# Altium schematic sheet BGT.SchDoc
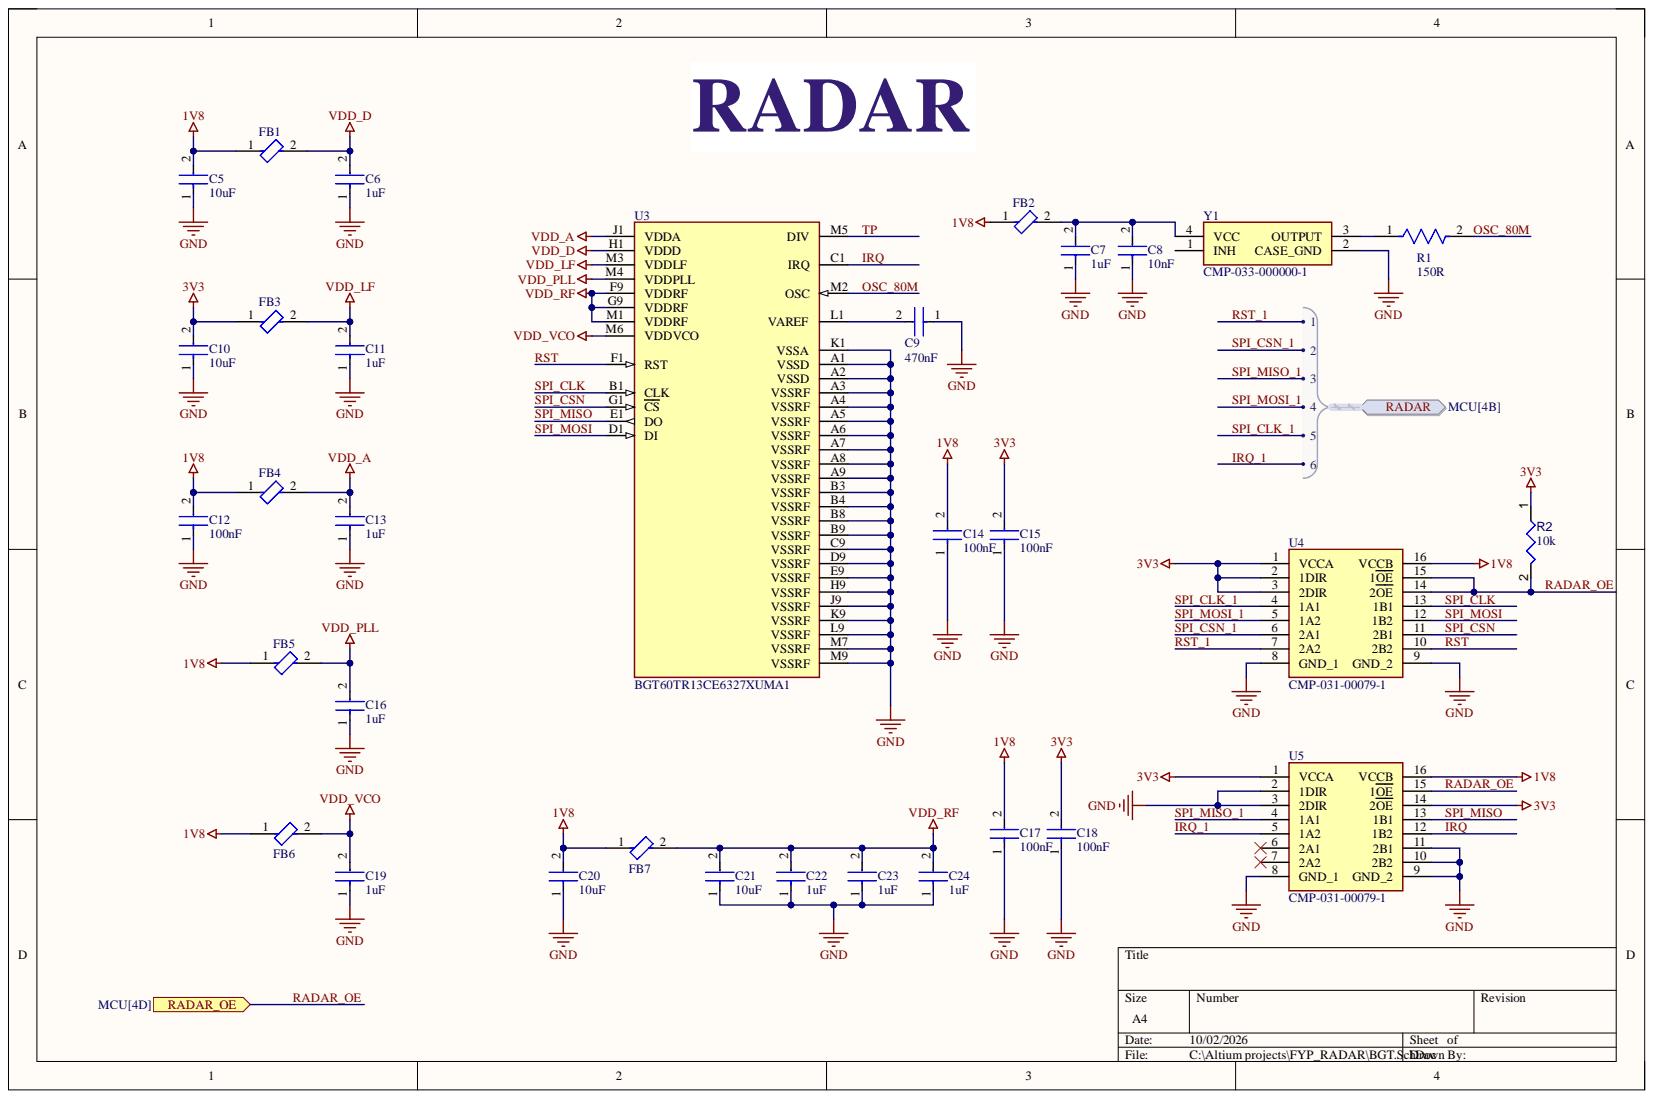
[diagram p0: BGT.SchDoc]

## Parts
C5  10uF  [FP-C0402C106M9PACTU-MFG]
C6  1uF  [FP-CC0402-MFG]
C7  1uF  [FP-CC0402-MFG]
C8  10nF  [FP-C0402-BB-MFG]
C9  470nF  [FP-CC0402-MFG]
C10  10uF  [FP-C0402C106M9PACTU-MFG]
C11  1uF  [FP-CC0402-MFG]
C12  100nF  [FP-C0402-BB-MFG]
C13  1uF  [FP-CC0402-MFG]
C14  100nF  [FP-C0402-BB-MFG]
C15  100nF  [FP-C0402-BB-MFG]
C16  1uF  [FP-CC0402-MFG]
C17  100nF  [FP-C0402-BB-MFG]
C18  100nF  [FP-C0402-BB-MFG]
C19  1uF  [FP-CC0402-MFG]
C20  10uF  [FP-C0402C106M9PACTU-MFG]
C21  10uF  [FP-C0402C106M9PACTU-MFG]
C22  1uF  [FP-CC0402-MFG]
C23  1uF  [FP-CC0402-MFG]
C24  1uF  [FP-CC0402-MFG]
FB1  MMZ1005B601CT000  [FP-MMZ1005-IPC_A]  (value not drawn)
FB2  MMZ1005B601CT000  [FP-MMZ1005-IPC_A]  (value not drawn)
FB3  MMZ1005B601CT000  [FP-MMZ1005-IPC_A]  (value not drawn)
FB4  MMZ1005B601CT000  [FP-MMZ1005-IPC_A]  (value not drawn)
FB5  MMZ1005B601CT000  [FP-MMZ1005-IPC_A]  (value not drawn)
FB6  MMZ1005S121CT000  [FP-MMZ1005-IPC_C]  (value not drawn)
FB7  MMZ1005S121CT000  [FP-MMZ1005-IPC_C]  (value not drawn)
R1  150R  [FP-AF0402-IPC_C]
R2  value 10k  [RESC2013X50X35ML15T15]  (hidden comment: Resistor 10k +/-1% 0805 125 mW)
U3  BGT60TR13CE6327XUMA1  [FP-PG-VF2BGA-40-MFG]
U4  CMP-031-00079-1  [SOP65P640X120-16N]
U5  CMP-031-00079-1  [SOP65P640X120-16N]
Y1  CMP-033-000000-1  [KC2016K800000C1GE00]

## Ports
RADAR, RADAR.1, RADAR.2, RADAR.3, RADAR.4, RADAR.5, RADAR.6, RADAR_OE

## Nets
1V8: C5.2 C12.2 C14.2 C17.2 C20.2 FB1.1 FB2.1 FB4.1 FB5.1 FB6.1 FB7.1 U4.16(VCCB) U5.16(VCCB)
3V3: C10.2 C15.2 C18.2 FB3.1 R2.1 U4.1(VCCA) U4.2(1DIR) U4.3(2DIR) U5.1(VCCA) U5.14(2OE)
GND: C5.1 C6.1 C7.1 C8.1 C9.1 C10.1 C11.1 C12.1 C13.1 C14.1 C15.1 C16.1 C17.1 C18.1 C19.1 C20.1 C21.1 C22.1 C23.1 C24.1 U3.A1(VSSD) U3.A2(VSSD) U3.A3(VSSRF) U3.A4(VSSRF) U3.A5(VSSRF) U3.A6(VSSRF) U3.A7(VSSRF) U3.A8(VSSRF) U3.A9(VSSRF) U3.B3(VSSRF) U3.B4(VSSRF) U3.B8(VSSRF) U3.B9(VSSRF) U3.C9(VSSRF) U3.D9(VSSRF) U3.E9(VSSRF) U3.H9(VSSRF) U3.J9(VSSRF) U3.K1(VSSA) U3.K9(VSSRF) U3.L9(VSSRF) U3.M7(VSSRF) U3.M9(VSSRF) U4.8(GND_1) U4.9(GND_2) U5.2(1DIR) U5.3(2DIR) U5.8(GND_1) U5.9(GND_2) U5.10(2B2) U5.11(2B1) Y1.2(CASE_GND)
VDD_A: C13.2 FB4.2 U3.J1(VDDA)
VDD_D: C6.2 FB1.2 U3.H1(VDDD)
VDD_LF: C11.2 FB3.2 U3.M3(VDDLF)
VDD_PLL: C16.2 FB5.2 U3.M4(VDDPLL)
VDD_RF: C21.2 C22.2 C23.2 C24.2 FB7.2 U3.F9(VDDRF) U3.G9(VDDRF) U3.M1(VDDRF)
VDD_VCO: C19.2 FB6.2 U3.M6(VDDVCO)
IRQ: U3.C1(IRQ) U5.12(1B2)
IRQ_1: U5.5(1A2) ; port RADAR
OSC_80M: R1.2 U3.M2(OSC)
RADAR_OE: R2.2 U4.14(2OE) U4.15(1OE) U5.15(1OE) ; port RADAR_OE
RST: U3.F1(RST) U4.10(2B2)
RST_1: U4.7(2A2) ; port RADAR
SPI_CLK: U3.B1(CLK) U4.13(1B1)
SPI_CLK_1: U4.4(1A1) ; port RADAR
SPI_CSN: U3.G1(CS) U4.11(2B1)
SPI_CSN_1: U4.6(2A1) ; port RADAR
SPI_MISO: U3.E1(DO) U5.13(1B1)
SPI_MISO_1: U5.4(1A1) ; port RADAR
SPI_MOSI: U3.D1(DI) U4.12(1B2)
SPI_MOSI_1: U4.5(1A2) ; port RADAR
TP: U3.M5(DIV)
NetC7_2: C7.2 C8.2 FB2.2 Y1.4(VCC)
NetC9_2: C9.2 U3.L1(VAREF)
NetR1_1: R1.1 Y1.3(OUTPUT)

Not connected: U5 6(2A1) 7(2A2); Y1 1(INH)

## Library data of the parts
C5 C10 C20 C21 = CMP-03020-034678-1: CAP CER 10UF 6.3V X5R 0402; Dielectric Material: Ceramic; Tolerance: 20%; Capacitance: 10uF; Case Code (Imperial): 0402; Case Code (Metric): 1005; Case/Package: 0402; Component Kind: Standard; Dielectric: X5R; Lead Free: Lead Free; Length: 0.039inch; Library Name: Altium Content Vault; Max Operating Temperature: 85°C; Min Operating Temperature: -55°C; Mount: Surface Mount; Packaging: Cut Tape; REACH SVHC: No SVHC; RoHS Compliant: Yes; Thickness: 0.028inch; Voltage Rating: 6.3V; Voltage Rating (DC): 6.3V; Width: 0.02inch
C6 C7 C11 C13 C16 C19 C22-C24 = CMP-03422-000064-1: CC0402 1 uF X5R 10.00% 16 V; Manufacturer: YAGEO; Manufacturer Part Number: CC0402KPX5R7BB105; Automotive Grade: NA; Material: Ceramic; Temperature Coefficient: 30ppm/°C; Tolerance: 0.1; Voltage Rating: 16 V; Automotive: No; Capacitance: 1 uF; Category: Cap; Component Kind: Standard; ComponentLink2Description: Datasheet; ComponentLink2URL: http://www.yageo.com/documents/recent/UPY-GP_NP0_16V-to-50V_16.pdf; Device Class L1: Passive Components; Device Class L2: Capacitors; Device Class L3: Ceramic Capacitors; Footprint Url: http://www.yageo.com/exep/pages/download/literatures/UPY-C_GEN_24.pdf; Height: 0.55mm; Ipc Land Pattern Name: CAPC10050X50; Lead Free: Yes; Library Name: Altium Content Vault; Octopart: https://octopart.com/cc0402kpx5r7bb105-yageo-89998189; Package: CC0402; RoHS: TRUE; Temperature: -55°C to +125°C; Temperature Characteristic: X5R; Temperature Range High: +125°C; Temperature Range Low: -55°C; Value: 1 uF
C8 = CMP-03020-001805-1: C0402 10,000 pF X7R 5.00% 25 V; Manufacturer: Kemet; Manufacturer Part Number: C0402C103J3RACAUTO; Material: Ceramic; Temperature Coefficient: 15%; Tolerance: 0.05; Voltage Rating: 25 V; Automotive: No; Capacitance: 10,000 pF; Category: Cap; Component Kind: Standard; ComponentLink2Description: Datasheet; ComponentLink2URL: https://content.kemet.com/datasheets/KEM_C1002_X7R_SMD.pdf; Device Class L1: Passive Components; Device Class L2: Capacitors; Device Class L3: Ceramic Capacitors; Height: 0.55mm; Ipc Land Pattern Name: CAPC10050X50; Lead Free: Yes; Library Name: Altium Content Vault; Mouser Description: Cap Ceramic 0.01uF 25V X7R 5% SMD 0402 125°C Paper T/R; Octopart: https://octopart.com/c0402c103j3racauto-kemet-95437015; Package: C0402-BB; RoHS: TRUE; Temperature: -55°C to +125°C; Temperature Characteristic: X7R; Temperature Range High: +125°C; Temperature Range Low: -55°C; Value: 10,000 pF
C9 = CMP-03422-000442-1: CAP CER 0.47UF 10V X7R 0402; Manufacturer: YAGEO; Manufacturer Part Number: CC0402KRX7R6BB474; Automotive Grade: NA; Material: Ceramic; Temperature Coefficient: 30ppm/°C; Tolerance: 0.1; Automotive: No; Capacitance: 470 nF; Category: Cap; Component Kind: Standard; ComponentLink2Description: Datasheet; ComponentLink2URL: http://www.yageo.com/documents/recent/UPY-GP_NP0_16V-to-50V_16.pdf; Device Class L1: Passive Components; Device Class L2: Capacitors; Device Class L3: Ceramic Capacitors; Digikey Description: CAP CER 0.47UF 10V X7R 0402; Footprint Url: http://www.yageo.com/exep/pages/download/literatures/UPY-C_GEN_24.pdf; Height: 0.55mm; Ipc Land Pattern Name: CAPC10050X50; Lead Free: Yes; Library Name: Altium Content Vault; Octopart: https://octopart.com/cc0402krx7r6bb474-yageo-61575634; Package: CC0402; RoHS: TRUE; Temperature: -55°C to +125°C; Temperature Characteristic: X7R; Temperature Range High: +125°C; Temperature Range Low: -55°C; Value: 470 nF; Voltage Rating: 10 V
C12 C14 C15 C17 C18 = CMP-03020-014070-2: CAP CER 0.1UF 25V X5R 0402; Manufacturer: Kemet; Manufacturer Part Number: C0402C104M3PAC7867; Capacitance: 0.10 μF; Material: Ceramic; Temperature Coefficient: 15%; Tolerance: 0.2; Value: 0.10 μF; Automotive: No; Category: Cap; Component Kind: Standard; ComponentLink2Description: Datasheet; ComponentLink2URL: https://content.kemet.com/datasheets/KEM_C1002_X7R_SMD.pdf; Device Class L1: Passive Components; Device Class L2: Capacitors; Device Class L3: Ceramic Capacitors; Digikey Description: CAP CER 0.1UF 25V X5R 0402; Height: 0.55mm; Ipc Land Pattern Name: CAPC10050X50; Lead Free: Yes; Library Name: Altium Content Vault; Octopart: https://octopart.com/c0402c104m3pac7867-kemet-84304880; Package: C0402-BB; RoHS: TRUE; Temperature: -55°C to +125°C; Temperature Characteristic: X5R; Temperature Range High: +125°C; Temperature Range Low: -55°C; Voltage Rating: 25 V
FB1-FB5 = CMP-08257-000327-1: Signal Line Ferrite Bead 600Ω @ 100MHz 200mA DCR 850mΩ SMD 0402; DC Resistance (DCR): 850mR; Impedance: 600R; Tolerance: 25%; Case Code (Imperial): 0402; Case Code (Metric): 1005; Case/Package: 0402; Component Kind: Standard; Core Material: Ferrite; Current Rating: 200mA; Height: 0.022inch; Lead Free: Lead Free; Length: 0.039inch; Library Name: Altium Content Vault; Max DC Current: 200mA; Mount: Surface Mount; Package Quantity: 1; Packaging: Tape and Reel; REACH SVHC: No SVHC; RoHS Compliant: Yes; Width: 0.02inch
FB6 FB7 = CMP-08257-000110-1: Signal Line Ferrite Bead 120Ω @ 100MHz 500mA DCR 220mΩ SMD 0402; DC Resistance (DCR): 250mR; Impedance: 120R; Tolerance: 25%; Case Code (Imperial): 0402; Case Code (Metric): 1005; Case/Package: 0402; Component Kind: Standard; Core Material: Ferrite; Current Rating: 500mA; Depth: 0.5mm; Height: 0.022inch; Lead Free: Lead Free; Length: 0.039inch; Library Name: Altium Content Vault; Max DC Current: 500mA; Mount: Surface Mount; Package Quantity: 1; Packaging: Tape and Reel; REACH SVHC: No SVHC; RoHS Compliant: Yes; Series: MMZ; Width: 0.02inch
R1 = CMP-03412-047359-1: Anti-Sulfurated Chip Resistors Automotive Grade 150Ω 1% 100ppm/°C 0402; Max Power Dissipation: 63mW; Case/Package: 0402; Component Kind: Standard; Features: Moisture Resistant; Height: 0.015inch; Length: 0.039inch; Library Name: Altium Content Vault; Max Operating Temperature: 155°C; Min Operating Temperature: -55°C; Number of Terminations: 2; Packaging: Tape and Reel; Resistance: 150R; Resistor Type: Thick Film; RoHS Compliant: Yes; Series: AF; Temperature Coefficient: 100ppm/°C; Tolerance: 1%; Width: 0.02inch
R2 = CMP-009-00018-5: Chip Resistor, 10 KOhm, +/- 1%, 125 mW, -55 to 155 degC, 0805 (2012 Metric); Power: 125mW; Voltage Rating: 150V; Case/Package: 0805; Component Kind: Standard; Max Operating Temperature: 155°C; Min Operating Temperature: -55°C; Mounting Technology: SM; Pins: 2; RoHS Compliant: True; Tolerance: 1%; Library Name: Kopithan Manoranjithan
U3 = CMP-32863-000008-1: RF TRANSCEIVER PG-VF2BGA-40; Component Kind: Standard; Library Name: Altium Content Vault
U4 U5 = CMP-031-00079-1: 4-Bit Dual-Supply Bus Transceiver with Configurable Voltage-Level Shifting and 3-State Outputs; Manufacturer_Name: Texas Instruments; Manufacturer_Part_Number: SN74AVC4T245PWR; Arrow Part Number: SN74AVC4T245PWR; Arrow Price/Stock: https://www.arrow.com/en/products/sn74avc4t245pwr/texas-instruments?region=nac; Component Kind: Standard; Datasheet Link: http://www.ti.com/lit/gpn/sn74avc4t245; Design Item ID: SN74AVC4T245PWR; Height: 1.2mm; Mouser Part Number: 595-SN74AVC4T245PWR; Mouser Price/Stock: https://www.mouser.co.uk/ProductDetail/Texas-Instruments/SN74AVC4T245PWR?qs=eXyjQBh9UFjikDDZFUGXXQ%3D%3D; Source: SamacSys.SchLib; Library Name: Kopithan Manoranjithan
Y1 = CMP-033-000000-1: Standard Clock Oscillators 80.0000MHZ Clock OSCIL CMOS; Manufacturer_Name: Kyocera AVX; Manufacturer_Part_Number: KC2016K80.0000C1GE00; Arrow Part Number: KC2016K80.0000C1GE00; Arrow Price/Stock: https://www.arrow.com/en/products/kc2016k80.0000c1ge00/avx?region=nac; Component Kind: Standard; Datasheet Link: http://global.kyocera.com/prdct/electro/product/pdf/clock_k_e.pdf; Design Item ID: KC2016K80.0000C1GE00; Height: 1mm; Mouser Part Number: 581-KC2016K80.0C1GE; Mouser Price/Stock: https://www.mouser.co.uk/ProductDetail/KYOCERA-AVX/KC2016K80.0000C1GE00?qs=16s%2F1Hm5%252BnezlxMjY8N0AA%3D%3D; Source: SamacSys.SchLib; Library Name: Kopithan Manoranjithan
